# Altium schematic sheet sdram.SchDoc(sdram)
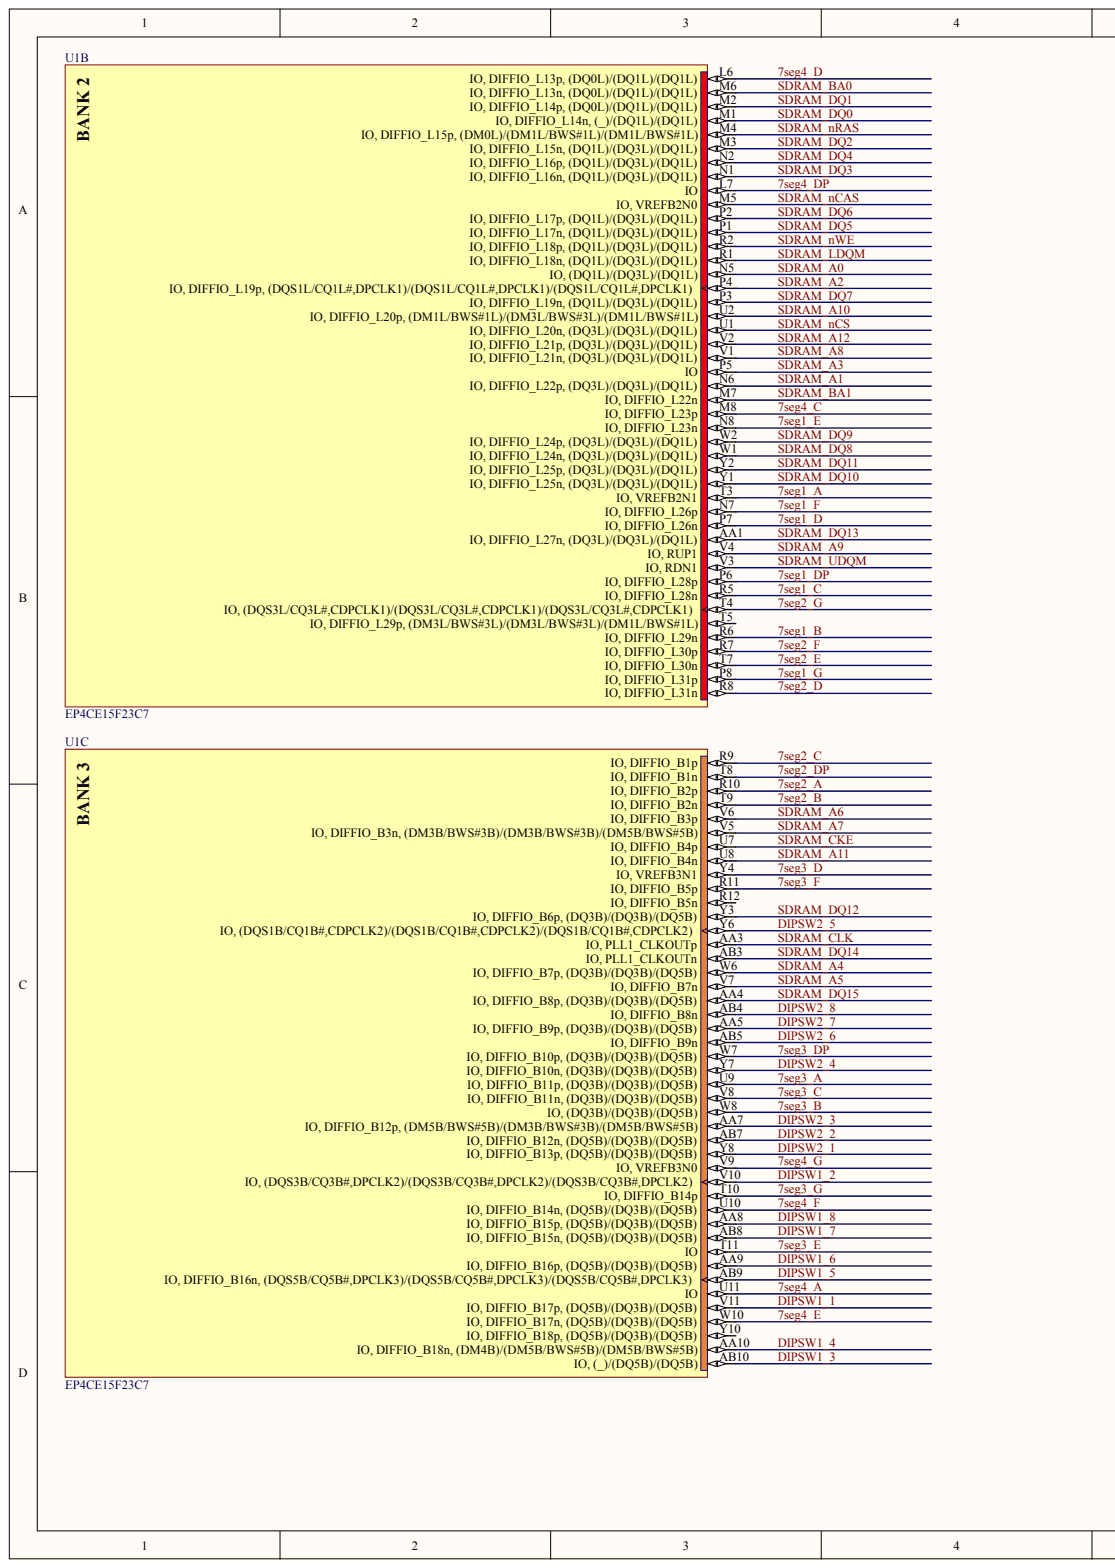
[diagram p0: sdram.SchDoc, left]
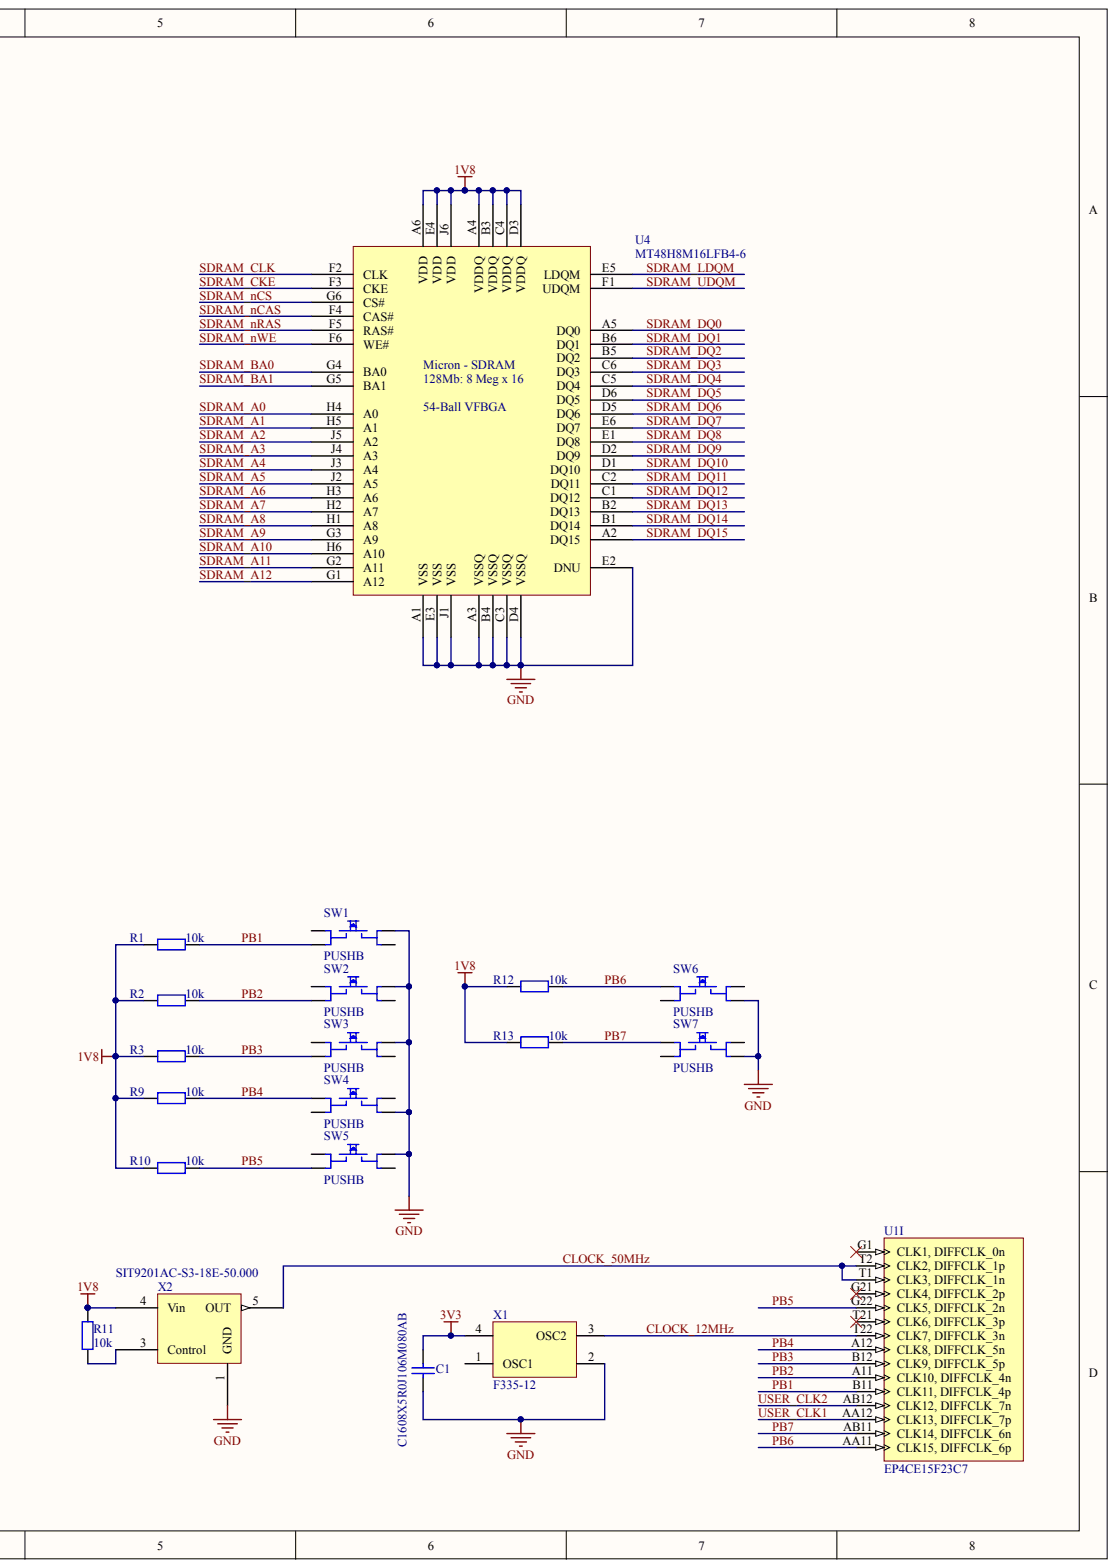
[diagram p1: sdram.SchDoc, right]

## Parts
C1  C1608X5R0J106M080AB  [CAPC1608X80]
R1  10k  [RESC1005[0402]X35]
R2  10k  [RESC1005[0402]X35]
R3  10k  [RESC1005[0402]X35]
R9  10k  [RESC1005[0402]X35]
R10  10k  [RESC1005[0402]X35]
R11  10k  [RESC1005[0402]X35]
R12  10k  [RESC1005[0402]X35]
R13  10k  [RESC1005[0402]X35]
SW1  PUSHB  [PUSHBT]
SW2  PUSHB  [PUSHBT]
SW3  PUSHB  [PUSHBT]
SW4  PUSHB  [PUSHBT]
SW5  PUSHB  [PUSHBT]
SW6  PUSHB  [PUSHBT]
SW7  PUSHB  [PUSHBT]
U1  EP4CE15F23C7  [FBGA484_A-240]  (parts B,C,I)
U4  MT48H8M16LFB4-6  [BGA54C80P9X9_800X800X75]
X1  F335-12  [FXO-HC33]
X2  SIT9201AC-S3-18E-50.000  [SOT95P270X145-5N]

## Nets
1V8: R1.1 R2.1 R3.1 R9.1 R10.1 R11.1 R12.1 R13.1 U4.A4(VDDQ) U4.A6(VDD) U4.B3(VDDQ) U4.C4(VDDQ) U4.D3(VDDQ) U4.E4(VDD) U4.J6(VDD) X2.4(Vin)
3V3: C1.2 X1.4
GND: C1.1 SW1.3 SW2.3 SW3.3 SW4.4 SW5.3 SW6.4 SW7.4 U4.A1(VSS) U4.A3(VSSQ) U4.B4(VSSQ) U4.C3(VSSQ) U4.D4(VSSQ) U4.E2(DNU) U4.E3(VSS) U4.J1(VSS) X1.2 X2.1(GND)
7SEG1_A: U1.T3(IO,_VREFB2N1)
7SEG1_B: U1.R6(IO,_DIFFIO_L29n)
7SEG1_C: U1.R5(IO,_DIFFIO_L28n)
7SEG1_D: U1.P7(IO,_DIFFIO_L26n)
7SEG1_DP: U1.P6(IO,_DIFFIO_L28p)
7SEG1_E: U1.N8(IO,_DIFFIO_L23n)
7SEG1_F: U1.N7(IO,_DIFFIO_L26p)
7SEG1_G: U1.P8(IO,_DIFFIO_L31p)
7SEG2_A: U1.R10(IO,_DIFFIO_B2p)
7SEG2_B: U1.T9(IO,_DIFFIO_B2n)
7SEG2_C: U1.R9(IO,_DIFFIO_B1p)
7SEG2_D: U1.R8(IO,_DIFFIO_L31n)
7SEG2_DP: U1.T8(IO,_DIFFIO_B1n)
7SEG2_E: U1.T7(IO,_DIFFIO_L30n)
7SEG2_F: U1.R7(IO,_DIFFIO_L30p)
7SEG2_G: U1.T4(IO,_(DQS3L/CQ3L#,CDPCLK1)/(DQS3L/CQ3L#,CDPCLK1)/(DQS3L/CQ3L#,CDPCLK1))
7SEG3_A: U1.U9(IO,_DIFFIO_B11p,_(DQ3B)/(DQ3B)/(DQ5B))
7SEG3_B: U1.W8(IO,_(DQ3B)/(DQ3B)/(DQ5B))
7SEG3_C: U1.V8(IO,_DIFFIO_B11n,_(DQ3B)/(DQ3B)/(DQ5B))
7SEG3_D: U1.Y4(IO,_VREFB3N1)
7SEG3_DP: U1.W7(IO,_DIFFIO_B10p,_(DQ3B)/(DQ3B)/(DQ5B))
7SEG3_E: U1.T11(IO)
7SEG3_F: U1.R11(IO,_DIFFIO_B5p)
7SEG3_G: U1.T10(IO,_DIFFIO_B14p)
7SEG4_A: U1.U11(IO)
7SEG4_C: U1.M8(IO,_DIFFIO_L23p)
7SEG4_D: U1.L6(IO,_DIFFIO_L13p,_(DQ0L)/(DQ1L)/(DQ1L))
7SEG4_DP: U1.L7(IO)
7SEG4_E: U1.W10(IO,_DIFFIO_B17n,_(DQ5B)/(DQ3B)/(DQ5B))
7SEG4_F: U1.U10(IO,_DIFFIO_B14n,_(DQ5B)/(DQ3B)/(DQ5B))
7SEG4_G: U1.V9(IO,_VREFB3N0)
CLOCK_12MHZ: U1.T22(CLK7,_DIFFCLK_3n) X1.3(OSC2)
CLOCK_50MHZ: U1.T1(CLK3,_DIFFCLK_1n) U1.T2(CLK2,_DIFFCLK_1p) X2.5(OUT)
DIPSW1_1: U1.V11(IO,_DIFFIO_B17p,_(DQ5B)/(DQ3B)/(DQ5B))
DIPSW1_2: U1.V10(IO,_(DQS3B/CQ3B#,DPCLK2)/(DQS3B/CQ3B#,DPCLK2)/(DQS3B/CQ3B#,DPCLK2))
DIPSW1_3: U1.AB10(IO,_(_)/(DQ5B)/(DQ5B))
DIPSW1_4: U1.AA10(IO,_DIFFIO_B18n,_(DM4B)/(DM5B/BWS#5B)/(DM5B/BWS#5B))
DIPSW1_5: U1.AB9(IO,_DIFFIO_B16n,_(DQS5B/CQ5B#,DPCLK3)/(DQS5B/CQ5B#,DPCLK3)/(DQS5B/CQ5B#,DPCLK3))
DIPSW1_6: U1.AA9(IO,_DIFFIO_B16p,_(DQ5B)/(DQ3B)/(DQ5B))
DIPSW1_7: U1.AB8(IO,_DIFFIO_B15n,_(DQ5B)/(DQ3B)/(DQ5B))
DIPSW1_8: U1.AA8(IO,_DIFFIO_B15p,_(DQ5B)/(DQ3B)/(DQ5B))
DIPSW2_1: U1.Y8(IO,_DIFFIO_B13p,_(DQ5B)/(DQ3B)/(DQ5B))
DIPSW2_2: U1.AB7(IO,_DIFFIO_B12n,_(DQ5B)/(DQ3B)/(DQ5B))
DIPSW2_3: U1.AA7(IO,_DIFFIO_B12p,_(DM5B/BWS#5B)/(DM3B/BWS#3B)/(DM5B/BWS#5B))
DIPSW2_4: U1.Y7(IO,_DIFFIO_B10n,_(DQ3B)/(DQ3B)/(DQ5B))
DIPSW2_5: U1.Y6(IO,_(DQS1B/CQ1B#,CDPCLK2)/(DQS1B/CQ1B#,CDPCLK2)/(DQS1B/CQ1B#,CDPCLK2))
DIPSW2_6: U1.AB5(IO,_DIFFIO_B9n)
DIPSW2_7: U1.AA5(IO,_DIFFIO_B9p,_(DQ3B)/(DQ3B)/(DQ5B))
DIPSW2_8: U1.AB4(IO,_DIFFIO_B8n)
PB1: R1.2 SW1.2 U1.B11(CLK11,_DIFFCLK_4p)
PB2: R2.2 SW2.2 U1.A11(CLK10,_DIFFCLK_4n)
PB3: R3.2 SW3.2 U1.B12(CLK9,_DIFFCLK_5p)
PB4: R9.2 SW4.1 U1.A12(CLK8,_DIFFCLK_5n)
PB5: R10.2 SW5.2 U1.G22(CLK5,_DIFFCLK_2n)
PB6: R12.2 SW6.1 U1.AA11(CLK15,_DIFFCLK_6p)
PB7: R13.2 SW7.1 U1.AB11(CLK14,_DIFFCLK_6n)
SDRAM_A0: U1.N5(IO,_(DQ1L)/(DQ3L)/(DQ1L)) U4.H4(A0)
SDRAM_A1: U1.N6(IO,_DIFFIO_L22p,_(DQ3L)/(DQ3L)/(DQ1L)) U4.H5(A1)
SDRAM_A2: U1.P4(IO,_DIFFIO_L19p,_(DQS1L/CQ1L#,DPCLK1)/(DQS1L/CQ1L#,DPCLK1)/(DQS1L/CQ1L#,DPCLK1)) U4.J5(A2)
SDRAM_A3: U1.P5(IO) U4.J4(A3)
SDRAM_A4: U1.W6(IO,_DIFFIO_B7p,_(DQ3B)/(DQ3B)/(DQ5B)) U4.J3(A4)
SDRAM_A5: U1.V7(IO,_DIFFIO_B7n) U4.J2(A5)
SDRAM_A6: U1.V6(IO,_DIFFIO_B3p) U4.H3(A6)
SDRAM_A7: U1.V5(IO,_DIFFIO_B3n,_(DM3B/BWS#3B)/(DM3B/BWS#3B)/(DM5B/BWS#5B)) U4.H2(A7)
SDRAM_A8: U1.V1(IO,_DIFFIO_L21n,_(DQ3L)/(DQ3L)/(DQ1L)) U4.H1(A8)
SDRAM_A9: U1.V4(IO,_RUP1) U4.G3(A9)
SDRAM_A10: U1.U2(IO,_DIFFIO_L20p,_(DM1L/BWS#1L)/(DM3L/BWS#3L)/(DM1L/BWS#1L)) U4.H6(A10)
SDRAM_A11: U1.U8(IO,_DIFFIO_B4n) U4.G2(A11)
SDRAM_A12: U1.V2(IO,_DIFFIO_L21p,_(DQ3L)/(DQ3L)/(DQ1L)) U4.G1(A12)
SDRAM_BA0: U1.M6(IO,_DIFFIO_L13n,_(DQ0L)/(DQ1L)/(DQ1L)) U4.G4(BA0)
SDRAM_BA1: U1.M7(IO,_DIFFIO_L22n) U4.G5(BA1)
SDRAM_CKE: U1.U7(IO,_DIFFIO_B4p) U4.F3(CKE)
SDRAM_CLK: U1.AA3(IO,_PLL1_CLKOUTp) U4.F2(CLK)
SDRAM_DQ0: U1.M1(IO,_DIFFIO_L14n,_(_)/(DQ1L)/(DQ1L)) U4.A5(DQ0)
SDRAM_DQ1: U1.M2(IO,_DIFFIO_L14p,_(DQ0L)/(DQ1L)/(DQ1L)) U4.B6(DQ1)
SDRAM_DQ2: U1.M3(IO,_DIFFIO_L15n,_(DQ1L)/(DQ3L)/(DQ1L)) U4.B5(DQ2)
SDRAM_DQ3: U1.N1(IO,_DIFFIO_L16n,_(DQ1L)/(DQ3L)/(DQ1L)) U4.C6(DQ3)
SDRAM_DQ4: U1.N2(IO,_DIFFIO_L16p,_(DQ1L)/(DQ3L)/(DQ1L)) U4.C5(DQ4)
SDRAM_DQ5: U1.P1(IO,_DIFFIO_L17n,_(DQ1L)/(DQ3L)/(DQ1L)) U4.D6(DQ5)
SDRAM_DQ6: U1.P2(IO,_DIFFIO_L17p,_(DQ1L)/(DQ3L)/(DQ1L)) U4.D5(DQ6)
SDRAM_DQ7: U1.P3(IO,_DIFFIO_L19n,_(DQ1L)/(DQ3L)/(DQ1L)) U4.E6(DQ7)
SDRAM_DQ8: U1.W1(IO,_DIFFIO_L24n,_(DQ3L)/(DQ3L)/(DQ1L)) U4.E1(DQ8)
SDRAM_DQ9: U1.W2(IO,_DIFFIO_L24p,_(DQ3L)/(DQ3L)/(DQ1L)) U4.D2(DQ9)
SDRAM_DQ10: U1.Y1(IO,_DIFFIO_L25n,_(DQ3L)/(DQ3L)/(DQ1L)) U4.D1(DQ10)
SDRAM_DQ11: U1.Y2(IO,_DIFFIO_L25p,_(DQ3L)/(DQ3L)/(DQ1L)) U4.C2(DQ11)
SDRAM_DQ12: U1.Y3(IO,_DIFFIO_B6p,_(DQ3B)/(DQ3B)/(DQ5B)) U4.C1(DQ12)
SDRAM_DQ13: U1.AA1(IO,_DIFFIO_L27n,_(DQ3L)/(DQ3L)/(DQ1L)) U4.B2(DQ13)
SDRAM_DQ14: U1.AB3(IO,_PLL1_CLKOUTn) U4.B1(DQ14)
SDRAM_DQ15: U1.AA4(IO,_DIFFIO_B8p,_(DQ3B)/(DQ3B)/(DQ5B)) U4.A2(DQ15)
SDRAM_LDQM: U1.R1(IO,_DIFFIO_L18n,_(DQ1L)/(DQ3L)/(DQ1L)) U4.E5(LDQM)
SDRAM_NCAS: U1.M5(IO,_VREFB2N0) U4.F4(CAS#)
SDRAM_NCS: U1.U1(IO,_DIFFIO_L20n,_(DQ3L)/(DQ3L)/(DQ1L)) U4.G6(CS#)
SDRAM_NRAS: U1.M4(IO,_DIFFIO_L15p,_(DM0L)/(DM1L/BWS#1L)/(DM1L/BWS#1L)) U4.F5(RAS#)
SDRAM_NWE: U1.R2(IO,_DIFFIO_L18p,_(DQ1L)/(DQ3L)/(DQ1L)) U4.F6(WE#)
SDRAM_UDQM: U1.V3(IO,_RDN1) U4.F1(UDQM)
USER_CLK1: U1.AA12(CLK13,_DIFFCLK_7p)
USER_CLK2: U1.AB12(CLK12,_DIFFCLK_7n)
NetR11_2: R11.2 X2.3(Control)

Not connected: SW1 1 4; SW2 1 4; SW3 1 4; SW4 2 3; SW5 1 4; SW6 2 3; SW7 2 3; U1 G1(CLK1,_DIFFCLK_0n) G21(CLK4,_DIFFCLK_2p) R12(IO,_DIFFIO_B5n) T5(IO,_DIFFIO_L29p,_(DM3L/BWS#3L)/(DM3L/BWS#3L)/(DM1L/BWS#1L)) T21(CLK6,_DIFFCLK_3p) Y10(IO,_DIFFIO_B18p,_(DQ5B)/(DQ3B)/(DQ5B)); X1 1(OSC1)

## Library data of the parts
C1 = Cap: Capacitor; Component Kind: Standard; LatestRevisionDate: 17-Jul-2002; LatestRevisionNote: Re-released for DXP Platform.; Library Name: Miscellaneous Devices.IntLib; PackageDescription: Radial Cap, Thru-Hole; 2 Leads; 0.3 in Pin Spacing; PackageReference: RAD-0.3; Published: 8-Jun-2000; Signal Integrity: Cap; Simulation: CAP; Value: C1608X5R0J106M080AB; Publisher: Altium Limited
R1-R3 R9-R13 = R: Resistor; Modified_by: BEP1; Component Kind: Standard; Library Name: RC_SMD_3D.SchLib; Modified: 10.2008; Value: 10k
SW1-SW7 = PUSB: Component Kind: Standard; VALUE: PUSHB; Library Name: geckoedu.SchLib
U1 = EP4CE15F23C7: Cyclone IV Family FPGA, 1.2V Core, 343 I/O Pins, 4 PLLs, 484-Pin FBGA, Speed Grade 7, Commercial Grade; Manufacturer: Altera; Code_JEDEC: MS-034-AAJ-1; Component Kind: Standard; ComponentLink1Description: Manufacturer URL; ComponentLink1URL: http://www.altera.com/; ComponentLink2Description: Handbook; ComponentLink2URL: http://www.altera.com/literature/hb/cyclone-iv/cyclone4-handbook.pdf; ComponentLink3Description: Pinout; ComponentLink3URL: http://www.altera.com/literature/lit-dp.jsp?category=cyc%204&showspreadsheet=y; ComponentLink4Description: Package Specification; ComponentLink4URL: http://www.altera.com/devicepackaging/04R00247-02.pdf; DatasheetVersion: ver1.6, Nov-2011; Library Name: Altera Cyclone IV E.IntLib; PackageDescription: 484-Pin FineLine Ball Grid Array (FBGA) - Wire Bond - A:2.40; PackageReference: FBGA484_A-240; PackageVersion: ver1.0, Jun-2011
U4 = SDRAM_MT48H8M16LFB4-6: SDRAM - MT48H8M16LFB4-6; Component Kind: Standard; Typ: MT48H8M16LFB4-6; Library Name: MSE_Hardware.SchLib
X1 = Crystal_12MHz: Component Kind: Standard; Library Name: Crystal.SchLib; VALUE: F335-12
X2 = SIT9201: Component Kind: Standard; VALUE: SIT9201AC-S3-18E-50.000; Library Name: geckoedu.SchLib
